# Altium schematic sheet Rikky-board_v0r0_RADIO.SchDoc
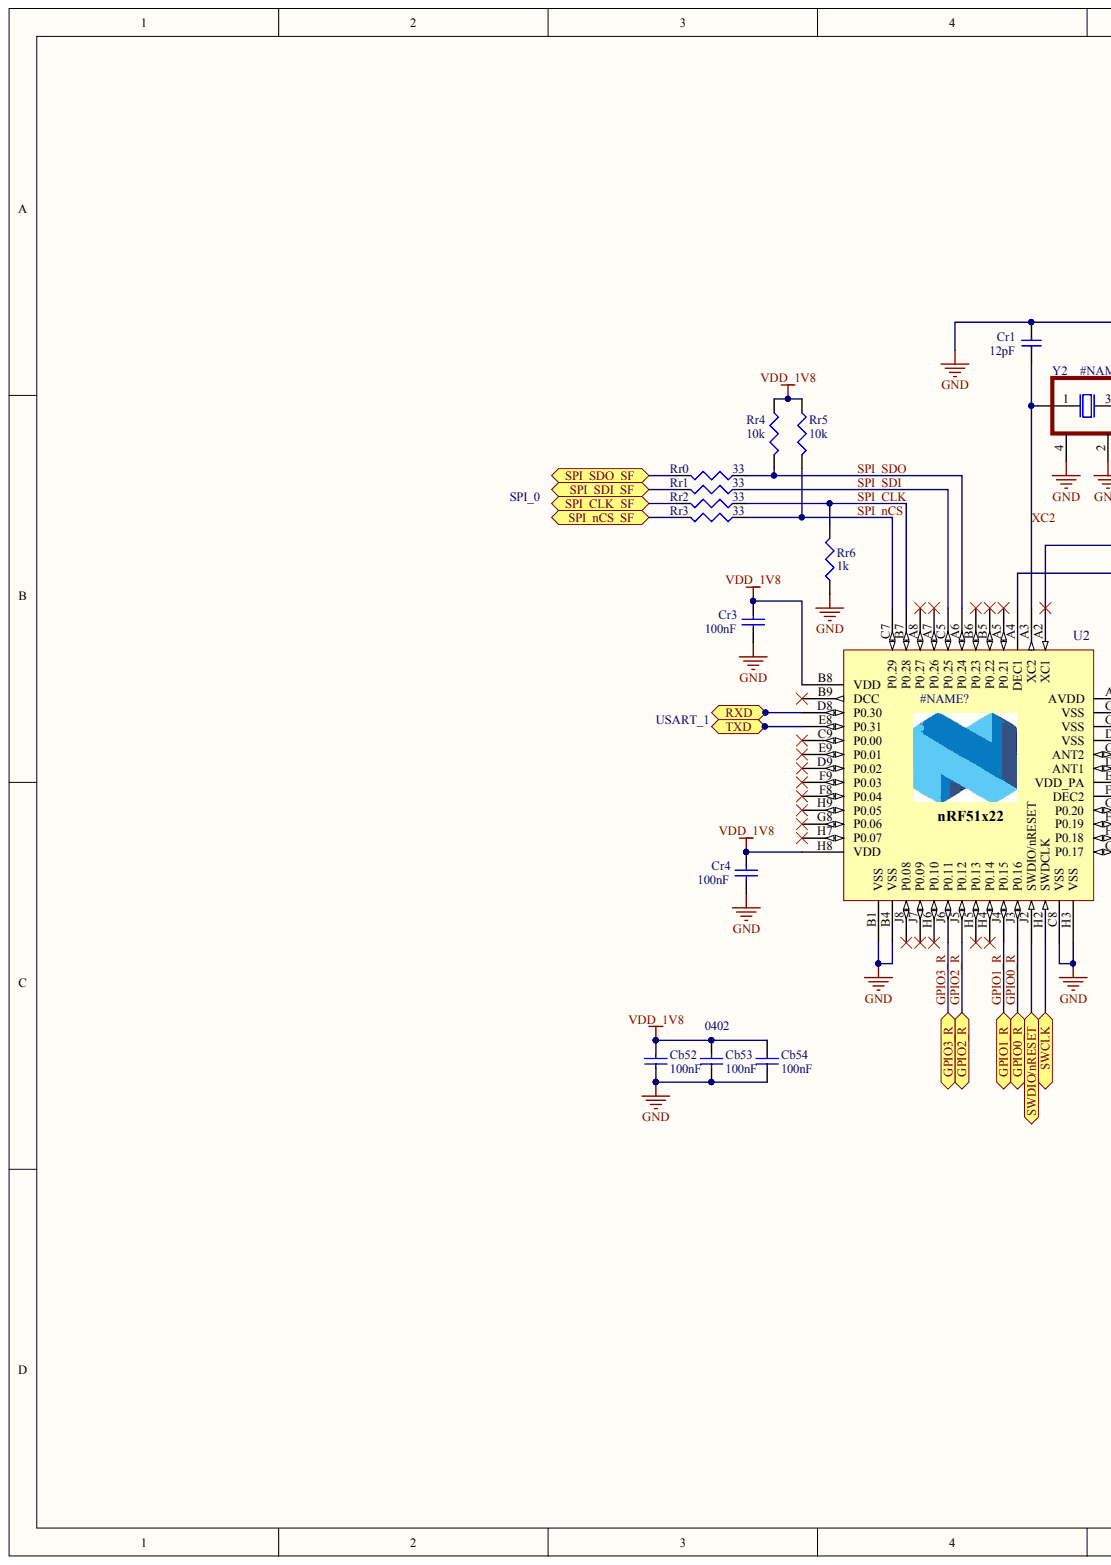
[diagram p0: Rikky-board_v0r0_RADIO.SchDoc, left]
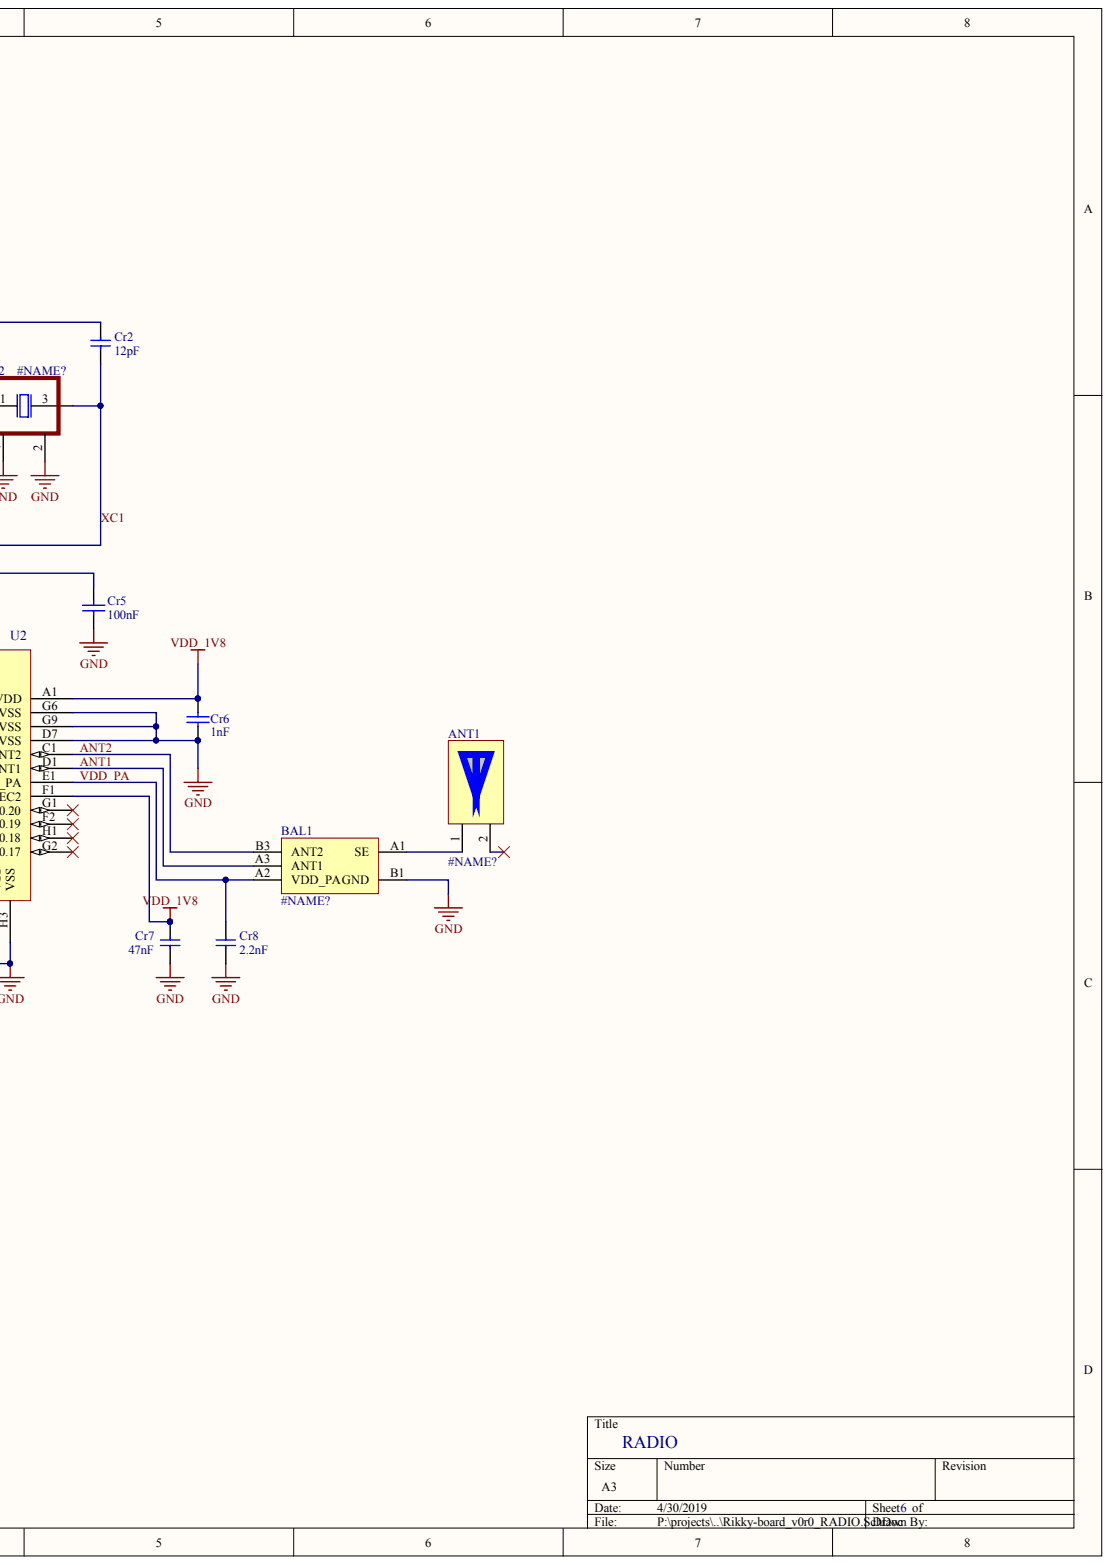
[diagram p1: Rikky-board_v0r0_RADIO.SchDoc, right]

## Parts
ANT1  ANT-2.45-CHP-T  (value not drawn)
BAL1  2450BM08B0003T  (value not drawn)
Cb52  value 100nF  (hidden comment: GCM155R71C104KA55D)
Cb53  value 100nF  (hidden comment: GCM155R71C104KA55D)
Cb54  value 100nF  (hidden comment: GCM155R71C104KA55D)
Cr1  value 12pF  (hidden comment: GRM1555C1H120FA01D)
Cr2  value 12pF  (hidden comment: GRM1555C1H120FA01D)
Cr3  value 100nF  (hidden comment: GCM155R71C104KA55D)
Cr4  value 100nF  (hidden comment: GCM155R71C104KA55D)
Cr5  value 100nF  (hidden comment: GCM155R71C104KA55D)
Cr6  value 1nF  (hidden comment: GRM155R61H102KA01D)
Cr7  value 47nF  (hidden comment: GRM155C71H473KE19D)
Cr8  value 2.2nF
Rr0  value 33  (hidden comment: ERJ-2RKF33R0X)
Rr1  value 33  (hidden comment: ERJ-2RKF33R0X)
Rr2  value 33  (hidden comment: ERJ-2RKF33R0X)
Rr3  value 33  (hidden comment: ERJ-2RKF33R0X)
Rr4  value 10k  (hidden comment: ERJ-2RKF1002X)
Rr5  value 10k  (hidden comment: ERJ-2RKF1002X)
Rr6  value 1k  (hidden comment: ERJ-2RKF1001X)
U2  NRF51822-CFAC-R  (value not drawn)
Y2  NX2520SA-16.000000MHZ  (value not drawn)

## Ports
GPIO0_R, GPIO1_R, GPIO2_R, GPIO3_R, RXD, SPI_CLK_SF, SPI_NCS_SF, SPI_SDI_SF, SPI_SDO_SF, SWCLK, SWDIO/NRESET, TXD

## Nets
GND: BAL1.B1(GND) Cb52.1 Cb53.1 Cb54.1 Cr1.2 Cr2.2 Cr3.1 Cr4.1 Cr5.1 Cr6.2 Cr7.1 Cr8.1 Rr6.1 U2.B1(VSS) U2.B4(VSS) U2.C8(VSS) U2.D7(VSS) U2.G6(VSS) U2.G9(VSS) U2.H3(VSS) Y2.2 Y2.4
VDD_1V8: Cb52.2 Cb53.2 Cb54.2 Cr3.2 Cr4.2 Cr6.1 Cr7.2 Rr4.1 Rr5.1 U2.A1(AVDD) U2.B8(VDD) U2.F1(DEC2) U2.H8(VDD)
ANT1: BAL1.A3(ANT1) U2.D1(ANT1)
ANT2: BAL1.B3(ANT2) U2.C1(ANT2)
GPIO0_R: U2.J3(P0.16) ; port GPIO0_R
GPIO1_R: U2.J4(P0.15) ; port GPIO1_R
GPIO2_R: U2.J5(P0.12) ; port GPIO2_R
GPIO3_R: U2.J6(P0.11) ; port GPIO3_R
RXD: U2.D8(P0.30) ; port RXD
SPI_CLK: Rr2.1 Rr6.2 U2.B7(P0.28)
SPI_CLK_SF: Rr2.2 ; port SPI_CLK_SF
SPI_NCS: Rr3.1 Rr5.2 U2.C7(P0.29)
SPI_SDI: Rr1.1 U2.C5(P0.25)
SPI_SDI_SF: Rr1.2 ; port SPI_SDI_SF
SPI_SDO: Rr0.1 Rr4.2 U2.A6(P0.24)
SPI_SDO_SF: Rr0.2 ; port SPI_SDO_SF
SWCLK: U2.H2(SWDCLK) ; port SWCLK
TXD: U2.E8(P0.31) ; port TXD
VDD_PA: BAL1.A2(VDD_PA) Cr8.2 U2.E1(VDD_PA)
XC1: Cr2.1 U2.A2(XC1) Y2.3
XC2: Cr1.1 U2.A3(XC2) Y2.1
NetANT1_1: ANT1.1 BAL1.A1(SE)
NetCr5_2: Cr5.2 U2.A4(DEC1)
NetRr3_2: Rr3.2 ; port SPI_NCS_SF
NetU2_J2: U2.J2(SWDIO/nRESET) ; port SWDIO/NRESET

Not connected: ANT1 2; U2 A5(P0.21) A7(P0.26) A8(P0.27) B5(P0.22) B6(P0.23) B9(DCC) C9(P0.00) D9(P0.02) E9(P0.01) F2(P0.19) F8(P0.04) F9(P0.03) G1(P0.20) G2(P0.17) G8(P0.06) H1(P0.18) H4(P0.14) H5(P0.13) H6(P0.10) H7(P0.07) H9(P0.05) J7(P0.09) J8(P0.08)

## Library data of the parts
ANT1 = (no symbol name): Manufacturer: Linx; Supplier PN: ANT-2.45-CHPCT-ND; Supplier: Digikey; Manufacturer PN: ANT-2.45-CHP-T
BAL1 = (no symbol name): Manufacturer: Johnson; Supplier PN: 712-1582-1-ND; Supplier: Digikey; Manufacturer PN: 2450BM08B0003T
Cb52-Cb54 Cr1-Cr7 = (no symbol name): Manufacturer: Murata; Supplier: Digikey
  Cb52-Cb54 Cr3-Cr5: Manufacturer PN: GCM155R71C104KA55D; Supplier PN: 490-4759-1-ND
  Cr1 Cr2: Manufacturer PN: GRM1555C1H120FA01D; Supplier PN: 490-6196-1-ND
  Cr6: Supplier PN: 490-6316-1-ND; Manufacturer PN: GRM155R61H102KA01D
  Cr7: Manufacturer PN: GRM155C71H473KE19D; Supplier PN: 490-11916-1-ND
Cr8 = (no symbol name): Manufacturer: Murata; Manufacturer PN: GRM155R61H222KA01D; Supplier: Digikey; Supplier PN: 490-8257-1-ND
Rr0-Rr6 = (no symbol name): Manufacturer: Panasonic; Power: 1/10W; Supplier: Digikey; Package: 0402
  Rr0-Rr3: Manufacturer PN: ERJ-2RKF33R0X; Supplier P/N: P33.0LCT-ND
  Rr4 Rr5: Manufacturer PN: ERJ-2RKF1002X; Supplier P/N: P10.0KLCT-ND
  Rr6: Manufacturer PN: ERJ-2RKF1001X; Supplier P/N: P1.00KLCT-ND
U2 = (no symbol name): Manufacturer: Nordic; Manufacturer PN: NRF51822-CFAC-R; Supplier: Digikey; Supplier PN: 1490-1041-1-ND
Y2 = (no symbol name): Manufacturer: NDK; Supplier PN: 644-1059-1-ND; Supplier: Digikey; Manufacturer PN: NX2520SA-16.000000MHZ
